# Altium schematic sheet SIM7600CE.SchDoc
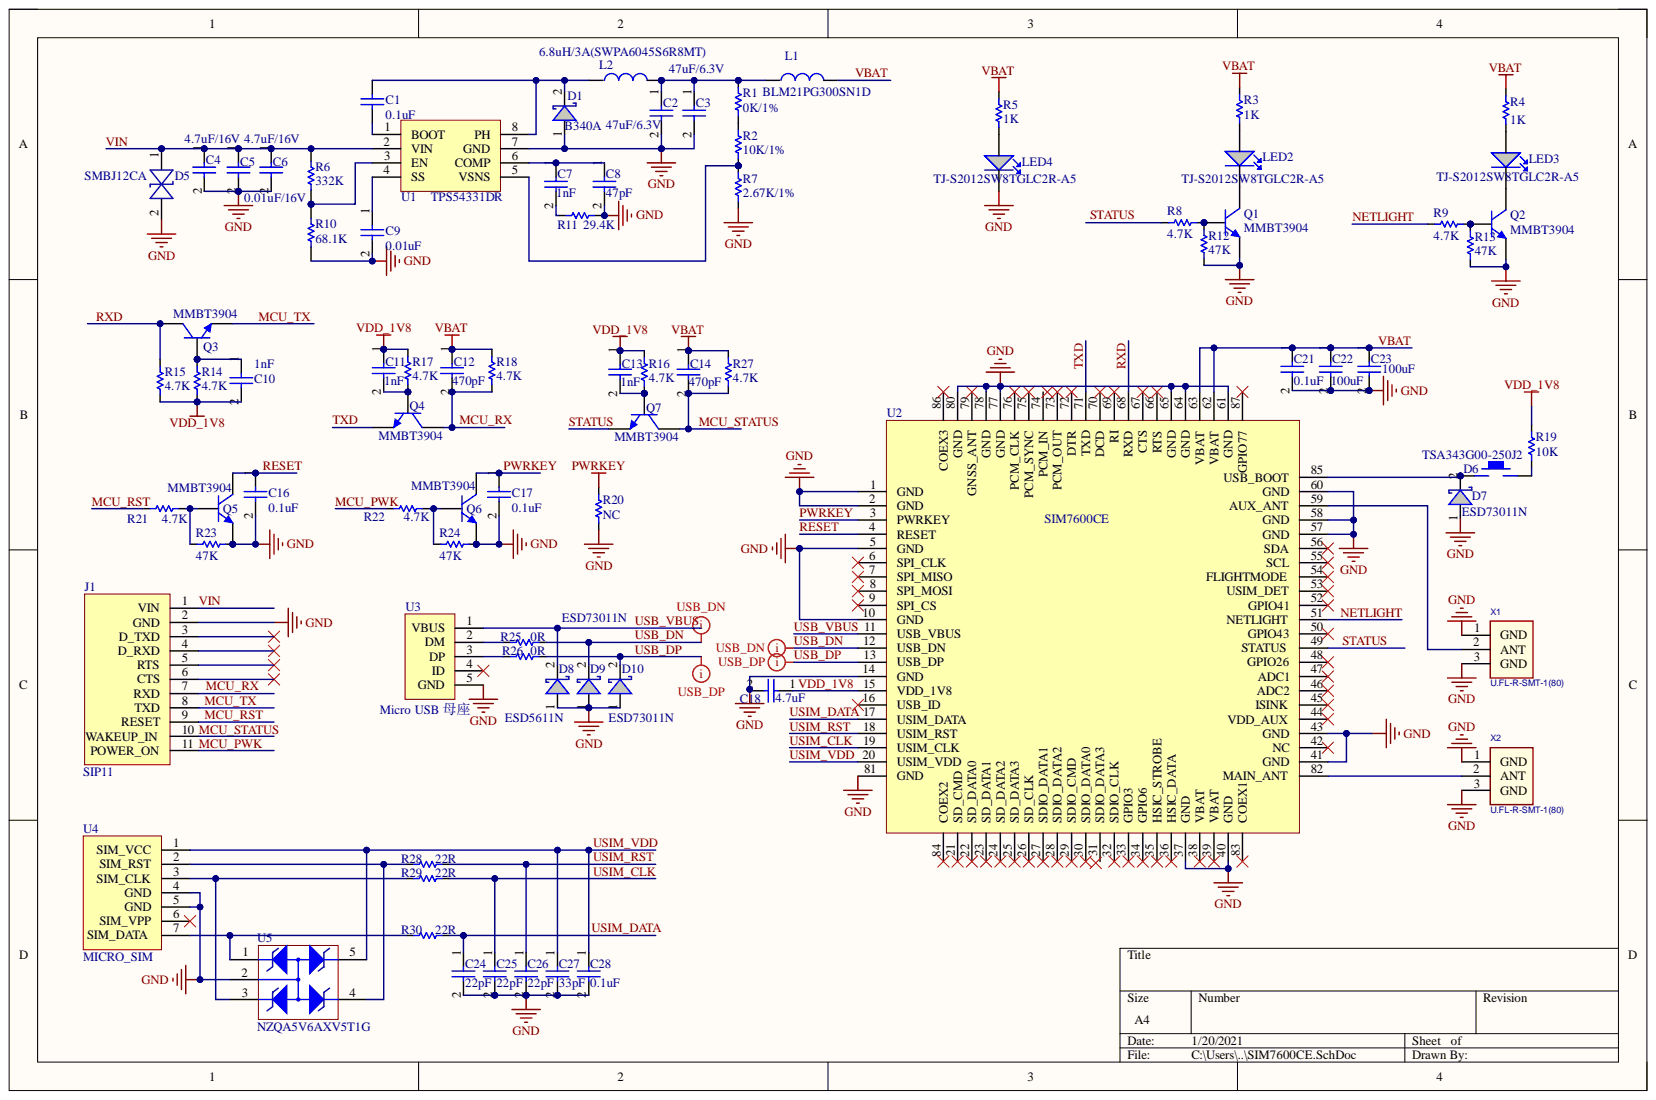
[diagram p0: SIM7600CE.SchDoc]

## Parts
C1  0.1uF  [C-0603]
C2  47uF/6.3V  [C-0603]
C3  47uF/6.3V  [C-0603]
C4  4.7uF/16V  [CASE-B_3528]
C5  4.7uF/16V  [CASE-B_3528]
C6  0.01uF/16V  [C-0603]
C7  1nF  [C-0603]
C8  47pF  [C-0603]
C9  0.01uF  [C-0603]
C10  1nF  [C-0603]
C11  1nF  [C-0603]
C12  470pF  [C-0603]
C13  1nF  [C-0603]
C14  470pF  [C-0603]
C16  0.1uF  [C-0603]
C17  0.1uF  [C-0603]
C18  4.7uF  [C-0603]
C21  0.1uF  [C-0603]
C22  100uF  [CASE-B_3528]
C23  100uF  [CASE-B_3528]
C24  22pF  [C-0603]
C25  22pF  [C-0603]
C26  22pF  [C-0603]
C27  33pF  [C-0603]
C28  0.1uF  [C-0603]
D1  B340A  [SMA(DO-214AC)]
D5  SMBJ12CA  [SMB(DO-214AA)]
D6  TSA343G00-250J2  [KEY]
D7  ESD73011N  [DFN1006-2L]
D8  ESD5611N  [DFN1006-2L]
D9  ESD73011N  [DFN1006-2L]
D10  ESD73011N  [DFN1006-2L]
J1  SIP11  [SIP11]
L1  BLM21PG300SN1D  [L-0805]
L2  6.8uH/3A(SWPA6045S6R8MT)  [SMD,6.0x6.0x4.5mm]
LED2  TJ-S2012SW8TGLC2R-A5  [D-0805]
LED3  TJ-S2012SW8TGLC2R-A5  [D-0805]
LED4  TJ-S2012SW8TGLC2R-A5  [D-0805]
Q1  MMBT3904  [SOT-23(SOT-23-3)]
Q2  MMBT3904  [SOT-23(SOT-23-3)]
Q3  MMBT3904  [SOT-23(SOT-23-3)]
Q4  MMBT3904  [SOT-23(SOT-23-3)]
Q5  MMBT3904  [SOT-23(SOT-23-3)]
Q6  MMBT3904  [SOT-23(SOT-23-3)]
Q7  MMBT3904  [SOT-23(SOT-23-3)]
R1  0K/1%  [R-0603]
R2  10K/1%  [R-0603]
R3  1K  [R-0603]
R4  1K  [R-0603]
R5  1K  [R-0603]
R6  332K  [R-0603]
R7  2.67K/1%  [R-0603]
R8  4.7K  [R-0603]
R9  4.7K  [R-0603]
R10  68.1K  [R-0603]
R11  29.4K  [R-0603]
R12  47K  [R-0603]
R13  47K  [R-0603]
R14  4.7K  [R-0603]
R15  4.7K  [R-0603]
R16  4.7K  [R-0603]
R17  4.7K  [R-0603]
R18  4.7K  [R-0603]
R19  10K  [R-0603]
R20  NC  [R-0603]
R21  4.7K  [R-0603]
R22  4.7K  [R-0603]
R23  47K  [R-0603]
R24  47K  [R-0603]
R25  0R  [R-0603]
R26  0R  [R-0603]
R27  4.7K  [R-0603]
R28  22R  [R-0603]
R29  22R  [R-0603]
R30  22R  [R-0603]
U1  TPS54331DR  [SOIC8-150MIL]
U2  SIM7600CE  [SIM7600CE]
U3  Micro USB 母座  [Micro USB]
U4  MICRO_SIM  [17.20x15.30x1.35]
U5  NZQA5V6AXV5T1G  [SOT-553]
X1  U.FL-R-SMT-1(80)  [U.FL-R-SMT-1(80)]
X2  U.FL-R-SMT-1(80)  [U.FL-R-SMT-1(80)]

## Nets
GND: C2.2 C3.2 C4.2 C5.2 C6.2 C8.2 C9.2 C16.2 C17.2 C18.2 C21.2 C22.2 C23.2 C24.2 C25.2 C26.2 C27.2 C28.2 D1.1 D5.2 D7.1 D8.1 D9.1 D10.1 J1.2(GND) LED4.2 Q1.2 Q2.2 Q5.2 Q6.2 R7.1 R10.1 R11.1 R12.1 R13.1 R20.2 R23.2 R24.2 U1.7(GND) U2.1(GND) U2.2(GND) U2.5(GND) U2.10(GND) U2.14(GND) U2.37(GND) U2.40(GND) U2.41(GND) U2.43(GND) U2.57(GND) U2.58(GND) U2.60(GND) U2.61(GND) U2.64(GND) U2.65(GND) U2.77(GND) U2.78(GND) U2.80(GND) U2.81(GND) U3.5(GND) U4.4(GND) U4.5(GND) U5.2 X1.1 X1.3 X2.1 X2.3
MCU_PWK: J1.11(POWER_ON) R22.1
MCU_RST: J1.9(RESET) R21.1
MCU_RX: C12.2 J1.7(RXD) Q4.3 R18.1
MCU_STATUS: C14.2 J1.10(WAKEUP_IN) Q7.3 R27.1
MCU_TX: J1.8(TXD) Q3.2
NETLIGHT: R9.1 U2.51(NETLIGHT)
PWRKEY: C17.1 Q6.3 R20.1 U2.3(PWRKEY)
RESET: C16.1 Q5.3 U2.4(RESET)
RXD: Q3.3 R15.1 U2.68(RXD)
STATUS: Q7.2 R8.1 U2.49(STATUS)
TXD: Q4.2 U2.71(TXD)
USB_DN: D9.2 R25.2 U2.12(USB_DN)
USB_DP: D10.2 R26.2 U2.13(USB_DP)
USB_VBUS: D8.2 U2.11(USB_VBUS) U3.1(VBUS)
USIM_CLK: C25.1 R29.2 U2.19(USIM_CLK)
USIM_DATA: C24.1 R30.2 U2.17(USIM_DATA)
USIM_RST: C26.1 R28.2 U2.18(USIM_RST)
USIM_VDD: C27.1 C28.1 U2.20(USIM_VDD) U4.1(SIM_VCC) U5.5
VBAT: C12.1 C14.1 C21.1 C22.1 C23.1 L1.2 R3.1 R4.1 R5.1 R18.2 R27.2 U2.62(VBAT) U2.63(VBAT)
VDD_1V8: C10.2 C11.1 C13.1 C18.1 R14.1 R15.2 R16.2 R17.2 R19.2 U2.15(VDD_1V8)
VIN: C4.1 C5.1 C6.1 D5.1 J1.1(VIN) R6.2 U1.2(VIN)
NetC1_1: C1.1 D1.2 L2.1 U1.8(PH)
NetC1_2: C1.2 U1.1(BOOT)
NetC2_1: C2.1 C3.1 L1.1 L2.2 R1.2
NetC7_1: C7.1 C8.1 U1.6(COMP)
NetC7_2: C7.2 R11.2
NetC9_1: C9.1 U1.4(SS)
NetC10_1: C10.1 Q3.1 R14.2
NetC11_2: C11.2 Q4.1 R17.1
NetC13_2: C13.2 Q7.1 R16.1
NetD6_1: D6.1 D7.2 U2.85(USB_BOOT)
NetD6_2: D6.2 R19.1
NetLED2_1: LED2.1 R3.2
NetLED2_2: LED2.2 Q1.3
NetLED3_1: LED3.1 R4.2
NetLED3_2: LED3.2 Q2.3
NetLED4_1: LED4.1 R5.2
NetQ1_1: Q1.1 R8.2 R12.2
NetQ2_1: Q2.1 R9.2 R13.2
NetQ5_1: Q5.1 R21.2 R23.1
NetQ6_1: Q6.1 R22.2 R24.1
NetR1_1: R1.1 R2.2
NetR2_1: R2.1 R7.2 U1.5(VSNS)
NetR6_1: R6.1 R10.2 U1.3(EN)
NetR25_1: R25.1 U3.2(DM)
NetR26_1: R26.1 U3.3(DP)
NetR28_1: R28.1 U4.2(SIM_RST) U5.4
NetR29_1: R29.1 U4.3(SIM_CLK) U5.3
NetR30_1: R30.1 U4.7(SIM_DATA) U5.1
NetU2_59: U2.59(AUX_ANT) X1.2
NetU2_82: U2.82(MAIN_ANT) X2.2

Not connected: J1 3(D_TXD) 4(D_RXD) 5(RTS) 6(CTS); U2 6(SPI_CLK) 7(SPI_MISO) 8(SPI_MOSI) 9(SPI_CS) 16(USB_ID) 21(SD_CMD) 22(SD_DATA0) 23(SD_DATA1) 24(SD_DATA2) 25(SD_DATA3) 26(SD_CLK) 27(SDIO_DATA1) 28(SDIO_DATA2) 29(SDIO_CMD) 30(SDIO_DATA0) 31(SDIO_DATA3) 32(SDIO_CLK) 33(GPIO3) 34(GPIO6) 35(HSIC_STROBE) 36(HSIC_DATA) 38(VBAT) 39(VBAT) 42(NC) 44(VDD_AUX) 45(ISINK) 46(ADC2) 47(ADC1) 48(GPIO26) 50(GPIO43) 52(GPIO41) 53(USIM_DET) 54(FLIGHTMODE) 55(SCL) 56(SDA) 66(RTS) 67(CTS) 69(RI) 70(DCD) 72(DTR) 73(PCM_OUT) 74(PCM_IN) 75(PCM_SYNC) 76(PCM_CLK) 79(GNSS_ANT) 83(COEX1) 84(COEX2) 86(COEX3) 87(GPIO77); U3 4(ID); U4 6(SIM_VPP)

## Library data of the parts
C1-C9 C11-C14 C16-C18 C22-C28 = Cap: Capacitor; Value: 104; Component Kind: Standard; LatestRevisionDate: 17-Jul-2002; LatestRevisionNote: Re-released for DXP Platform.; Library Name: SIM7600CE.SchLib; PackageReference: RAD-0.3; Published: 8-Jun-2000; Publisher: Altium Limited
  C1 C9: Signal Integrity: Cap; Simulation: CAP
C10 C21 = Cap: Capacitor; Component Kind: Standard; LatestRevisionDate: 17-Jul-2002; LatestRevisionNote: Re-released for DXP Platform.; Library Name: SIM7600CE.SchLib; PackageReference: RAD-0.3; Published: 8-Jun-2000; Publisher: Altium Limited
  C10: Value: 1nF
  C21: Value: 0.1uF
D1 D7-D10 = B340A: TVS二极管; Component Kind: Standard; Library Name: SIM7600CE.SchLib
D5 = SMBJ6.0CA: TVS二极管; Component Kind: Standard; Library Name: SIM7600CE.SchLib
D6 = KEY_M: Component Kind: Standard; Library Name: SIM7600CE.SchLib
J1 = SIP11: 排针; Component Kind: Standard; Library Name: SIM7600CE.SchLib
L1 L2 = Ind: 贴片电感; Manufacture 1: Chilisin(奇力新); Geometry.Height: 2.2 mm; PCB DECAL: LVS505020-4R7M-N; Component Kind: Standard; Library Name: SIM7600CE.SchLib
  L1: Value: BLM21PG300SN1D
  L2: Value: 6.8uH/3A
LED2-LED4 = LED: 发光二极管; Component Kind: Standard; Library Name: SIM7600CE.SchLib
Q1-Q7 = MMBT3904: NPN三极管; Code_JEDEC: TO-226-AA; Component Kind: Standard; LatestRevisionDate: 17-Jul-2002; LatestRevisionNote: Re-released for DXP Platform.; Library Name: SIM7600CE.SchLib; PackageReference: TO-226; Published: 8-Jun-2000; Publisher: Altium Limited
R1-R5 R7-R9 R11 R14-R22 R25-R30 = Res: Resistor; Value: 47K; Component Kind: Standard; LatestRevisionDate: 17-Jul-2002; LatestRevisionNote: Re-released for DXP Platform.; Library Name: SIM7600CE.SchLib; PackageReference: AXIAL-0.3; Published: 8-Jun-2000; Publisher: Altium Limited
R6 R10 = R-0603: Resistor; Value: 47K; Component Kind: Standard; LatestRevisionDate: 17-Jul-2002; LatestRevisionNote: Re-released for DXP Platform.; Library Name: SIM7600CE.SchLib; PackageReference: AXIAL-0.3; Published: 8-Jun-2000; Publisher: Altium Limited
R12 R13 R23 R24 = Res: Resistor; Component Kind: Standard; LatestRevisionDate: 17-Jul-2002; LatestRevisionNote: Re-released for DXP Platform.; Library Name: SIM7600CE.SchLib; PackageReference: AXIAL-0.3; Published: 8-Jun-2000; Value: 47K; Publisher: Altium Limited
U1 = TPS54331DR: DC-DC电源芯片; Component Kind: Standard; Library Name: SIM7600CE.SchLib
U2 = SIM7600CE: 4G模块; Component Kind: Standard; Library Name: SIM7600CE.SchLib
U3 = Micro-USB: Component Kind: Standard; Description: Micro-USB; Library Name: SIM7600CE.SchLib
U4 = MICRO_SIM: MICRO_SIM卡座(6P); Component Kind: Standard; Library Name: SIM7600CE.SchLib
U5 = NZQA5V6AXV5T1G: ESD二极管; Component Kind: Standard; Library Name: SIM7600CE.SchLib
X1 X2 = RF: 天线插座; Component Kind: Standard; Library Name: SIM7600CE.SchLib
